# Altium schematic sheet 12mPCIe.SchDoc
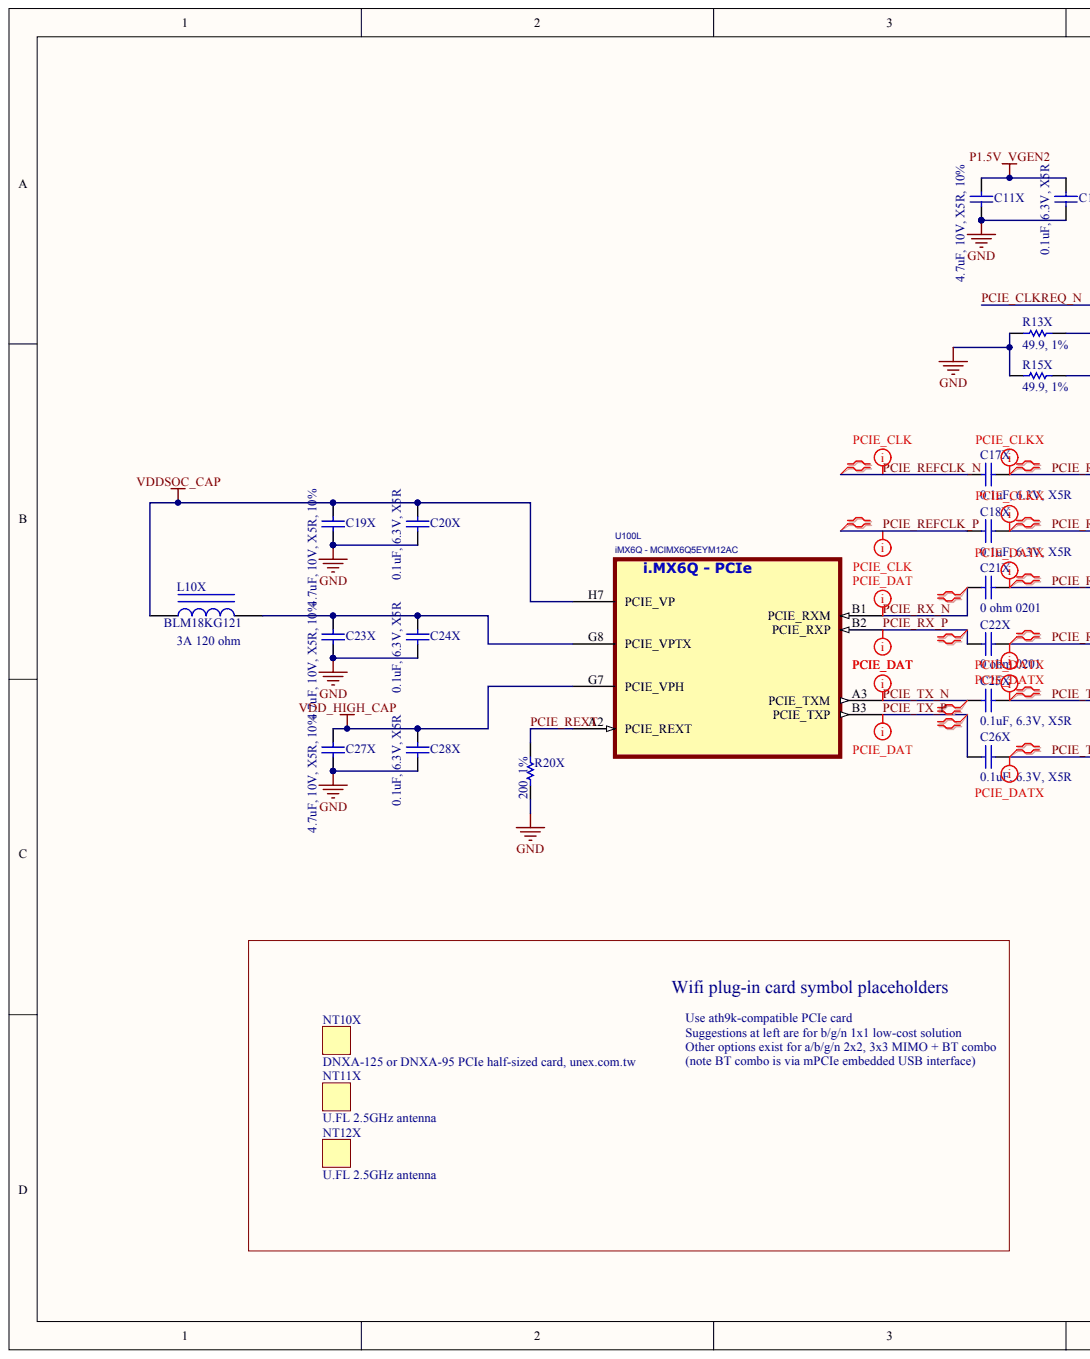
[diagram p0: 12mPCIe.SchDoc, left]
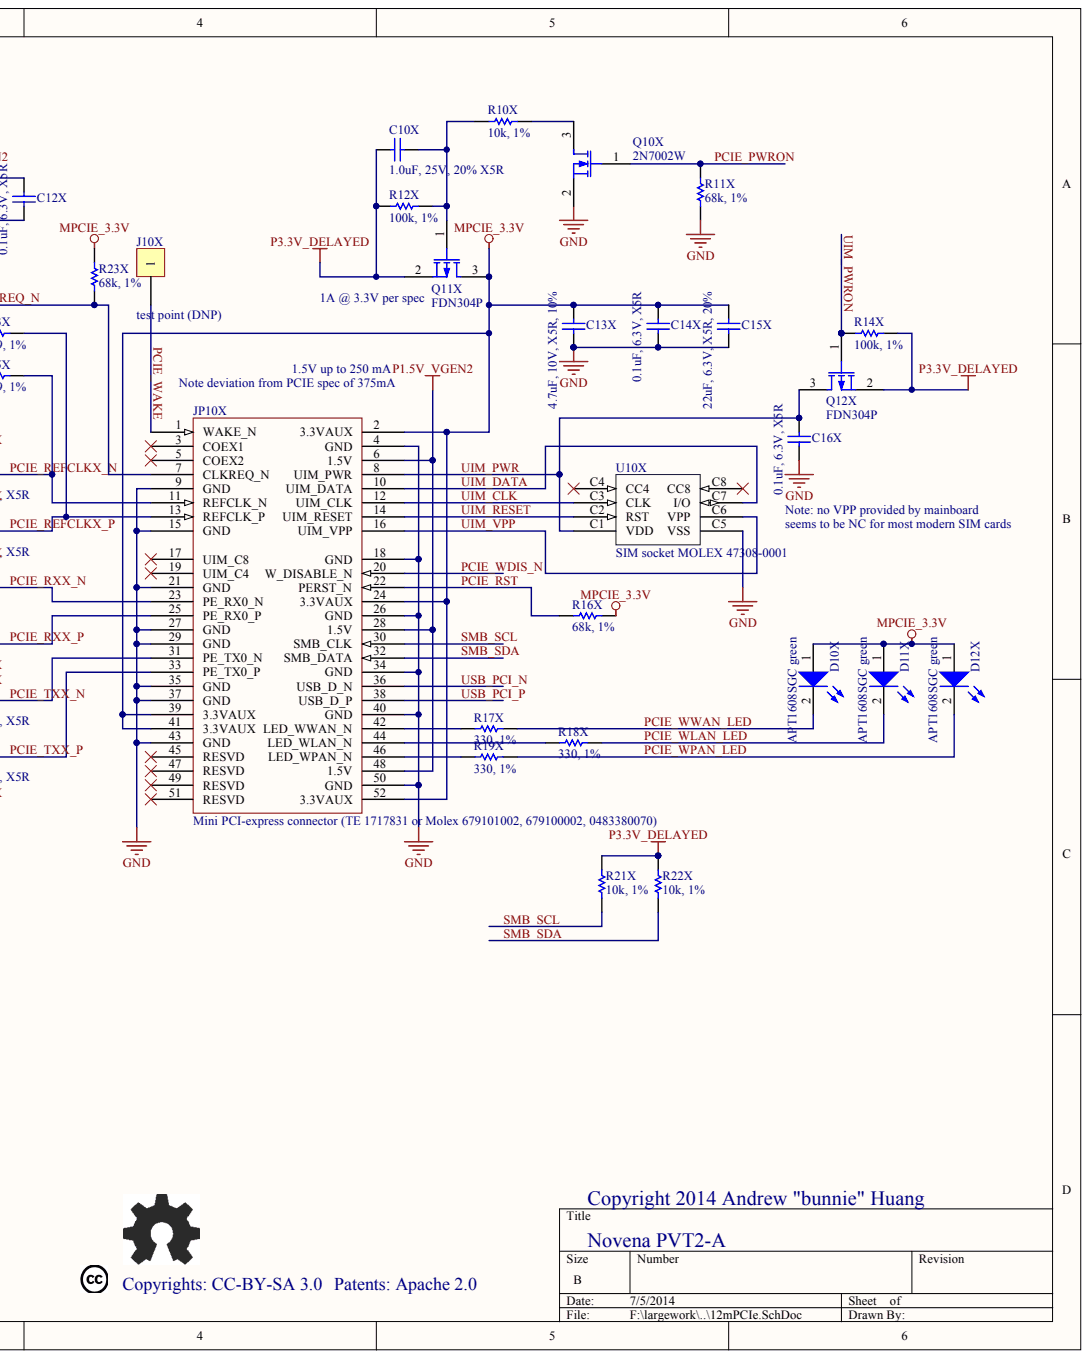
[diagram p1: 12mPCIe.SchDoc, right]

## Parts
C10X  1.0uF, 25V, 20% X5R
C11X  4.7uF, 10V, X5R, 10%
C12X  0.1uF, 6.3V, X5R
C13X  4.7uF, 10V, X5R, 10%
C14X  0.1uF, 6.3V, X5R
C15X  22uF, 6.3V, X5R, 20%
C16X  0.1uF, 6.3V, X5R
C17X  0.1uF, 6.3V, X5R
C18X  0.1uF, 6.3V, X5R
C19X  4.7uF, 10V, X5R, 10%
C20X  0.1uF, 6.3V, X5R
C21X  0 ohm 0201
C22X  0 ohm 0201
C23X  4.7uF, 10V, X5R, 10%
C24X  0.1uF, 6.3V, X5R
C25X  0.1uF, 6.3V, X5R
C26X  0.1uF, 6.3V, X5R
C27X  4.7uF, 10V, X5R, 10%
C28X  0.1uF, 6.3V, X5R
D10X  APT1608SGC green
D11X  APT1608SGC green
D12X  APT1608SGC green
J10X  test point (DNP)
JP10X  Mini PCI-express connector (TE 1717831 or Molex 679101002, 679100002, 0483380070)
L10X  BLM18KG121  value 3A 120 ohm
NT10X  DNXA-125 or DNXA-95 PCIe half-sized card, unex.com.tw
NT11X  U.FL 2.5GHz antenna
NT12X  U.FL 2.5GHz antenna
Q10X  2N7002W
Q11X  FDN304P
Q12X  FDN304P
R10X  10k, 1%
R11X  68k, 1%
R12X  100k, 1%
R13X  49.9, 1%
R14X  100k, 1%
R15X  49.9, 1%
R16X  68k, 1%
R17X  330, 1%
R18X  330, 1%
R19X  330, 1%
R20X  200, 1%
R21X  10k, 1%
R22X  10k, 1%
R23X  68k, 1%
U10X  SIM socket MOLEX 47308-0001
U100  iMX6Q - MCIMX6Q5EYM12AC  (part L)

## Nets
GND: C11X.2 C12X.2 C13X.2 C14X.2 C15X.2 C16X.2 C19X.2 C20X.2 C23X.2 C24X.2 C27X.2 C28X.2 JP10X.4(GND) JP10X.9(GND) JP10X.15(GND) JP10X.18(GND) JP10X.21(GND) JP10X.26(GND) JP10X.27(GND) JP10X.29(GND) JP10X.34(GND) JP10X.35(GND) JP10X.37(GND) JP10X.40(GND) JP10X.43(GND) JP10X.50(GND) Q10X.2 R11X.2 R13X.2 R15X.2 R20X.1 U10X.C5(VSS)
MPCIE_3.3V: C13X.1 C14X.1 C15X.1 D10X.1 D11X.1 D12X.1 JP10X.2(3.3VAUX) JP10X.24(3.3VAUX) JP10X.39(3.3VAUX) JP10X.41(3.3VAUX) JP10X.52(3.3VAUX) Q11X.3 R16X.1 R23X.2
P1.5V_VGEN2: C11X.1 C12X.1 JP10X.6(1.5V) JP10X.28(1.5V) JP10X.48(1.5V)
P3.3V_DELAYED: C10X.2 Q11X.2 Q12X.2 R12X.2 R14X.1 R21X.2 R22X.2
VDD_HIGH_CAP: C27X.1 C28X.1 U100.G7(PCIE_VPH)
VDDSOC_CAP: C19X.1 C20X.1 L10X.1 U100.H7(PCIE_VP)
PCIE_CLKREQ_N: JP10X.7(CLKREQ_N) R23X.1
PCIE_PWRON: Q10X.1 R11X.1
PCIE_REFCLK_N: C17X.2
PCIE_REFCLK_P: C18X.2
PCIE_REFCLKX_N: C17X.1 JP10X.11(REFCLK_N) R15X.1
PCIE_REFCLKX_P: C18X.1 JP10X.13(REFCLK_P) R13X.1
PCIE_REXT: R20X.2 U100.A2(PCIE_REXT)
PCIE_RST: JP10X.22(PERST_N) R16X.2
PCIE_RX_N: C21X.2 U100.B1(PCIE_RXM)
PCIE_RX_P: C22X.2 U100.B2(PCIE_RXP)
PCIE_RXX_N: C21X.1 JP10X.23(PE_RX0_N)
PCIE_RXX_P: C22X.1 JP10X.25(PE_RX0_P)
PCIE_TX_N: C25X.2 U100.A3(PCIE_TXM)
PCIE_TX_P: C26X.2 U100.B3(PCIE_TXP)
PCIE_TXX_N: C25X.1 JP10X.31(PE_TX0_N)
PCIE_TXX_P: C26X.1 JP10X.33(PE_TX0_P)
PCIE_WAKE: J10X.1 JP10X.1(WAKE_N)
PCIE_WDIS_N: JP10X.20(W_DISABLE_N)
PCIE_WLAN_LED: D11X.2 R18X.2
PCIE_WPAN_LED: D12X.2 R19X.2
PCIE_WWAN_LED: D10X.2 R17X.2
SMB_SCL: JP10X.30(SMB_CLK) R21X.1
SMB_SDA: JP10X.32(SMB_DATA) R22X.1
UIM_CLK: JP10X.12(UIM_CLK) U10X.C3(CLK)
UIM_DATA: JP10X.10(UIM_DATA) U10X.C7(I/O)
UIM_PWR: C16X.1 JP10X.8(UIM_PWR) Q12X.3 U10X.C1(VDD)
UIM_PWRON: Q12X.1 R14X.2
UIM_RESET: JP10X.14(UIM_RESET) U10X.C2(RST)
UIM_VPP: JP10X.16(UIM_VPP) U10X.C6(VPP)
USB_PCI_N: JP10X.36(USB_D_N)
USB_PCI_P: JP10X.38(USB_D_P)
NetC10X_1: C10X.1 Q11X.1 R10X.1 R12X.1
NetC23X_1: C23X.1 C24X.1 L10X.2 U100.G8(PCIE_VPTX)
NetJP10X_42: JP10X.42(LED_WWAN_N) R17X.1
NetJP10X_44: JP10X.44(LED_WLAN_N) R18X.1
NetJP10X_46: JP10X.46(LED_WPAN_N) R19X.1
NetQ10X_3: Q10X.3 R10X.2

Not connected: JP10X 3(COEX1) 5(COEX2) 17(UIM_C8) 19(UIM_C4) 45(RESVD) 47(RESVD) 49(RESVD) 51(RESVD); U10X C4(CC4) C8(CC8)

## Library data of the parts
R10X R11X R13X R15X R16X R17X R18X R19X R20X R21X R22X R23X = (no symbol name): Value: 100k; Published: 8-Jun-2000; LatestRevisionDate: 17-Jul-2002; LatestRevisionNote: Re-released for DXP Platform.; PackageReference: AXIAL-0.3; Publisher: Altium Limited
U100 = (no symbol name): AGILE_PN: TMP-WF-14526; MFG_NAME01: Freescale Semiconductor; MFG_PN01: PCIMX6Q6VV1A; PRE_APN: 344-01218; ROHS: Yes; HEIGHT: 2.16MM
C10X C11X C12X C13X C14X C15X C16X C17X C18X C19X C20X C21X C22X C23X C24X C25X C26X C27X C28X D10X D11X D12X J10X JP10X L10X NT10X NT11X NT12X Q10X Q11X Q12X R12X R14X U10X = (no symbol name)
  C10X C11X C12X C13X C14X C15X C16X C17X C18X C19X C20X C21X C22X C23X C24X C25X C26X C27X C28X: Published: 8-Jun-2000; LatestRevisionDate: 17-Jul-2002; LatestRevisionNote: Re-released for DXP Platform.; PackageReference: C1206
  D10X D11X D12X: Published: 8-Jun-2000; LatestRevisionDate: 17-Jul-2002; LatestRevisionNote: Re-released for DXP Platform.; PackageVersion: Feb-2002; PackageReference: 3.2X1.6X1.1
  L10X: Published: 24-Mar-1999; LatestRevisionDate: 17-Jul-2002; LatestRevisionNote: Re-released for DXP Platform.; PackageReference: AXIAL-0.9
  Q10X: Published: 8-Jun-2000; LatestRevisionDate: 17-Jul-2002; LatestRevisionNote: Re-released for DXP Platform.; PackageVersion: 1995/1996; PackageReference: E3
  Q11X Q12X: Published: 8-Jun-2000; LatestRevisionDate: 17-Jul-2002; LatestRevisionNote: Re-released for DXP Platform.; PackageVersion: 1995/1996; PackageReference: E3; PackageDescription: TO, Flat Index; 3 In-Line, Axial Leads; Body Dia. 4.6mm; Lead Dia. 0.5 mm (max)
  R12X R14X: Published: 8-Jun-2000; LatestRevisionDate: 17-Jul-2002; LatestRevisionNote: Re-released for DXP Platform.; PackageReference: AXIAL-0.3; Value: 100k
  C10X C11X C12X C13X C14X C15X C16X C17X C18X C19X C20X C21X C22X C23X C24X C25X C26X C27X C28X D10X D11X D12X L10X Q10X Q11X Q12X R12X R14X: Publisher: Altium Limited
